# Altium schematic sheet MCU_CONTROL.SchDoc(MCU_CONTROL)
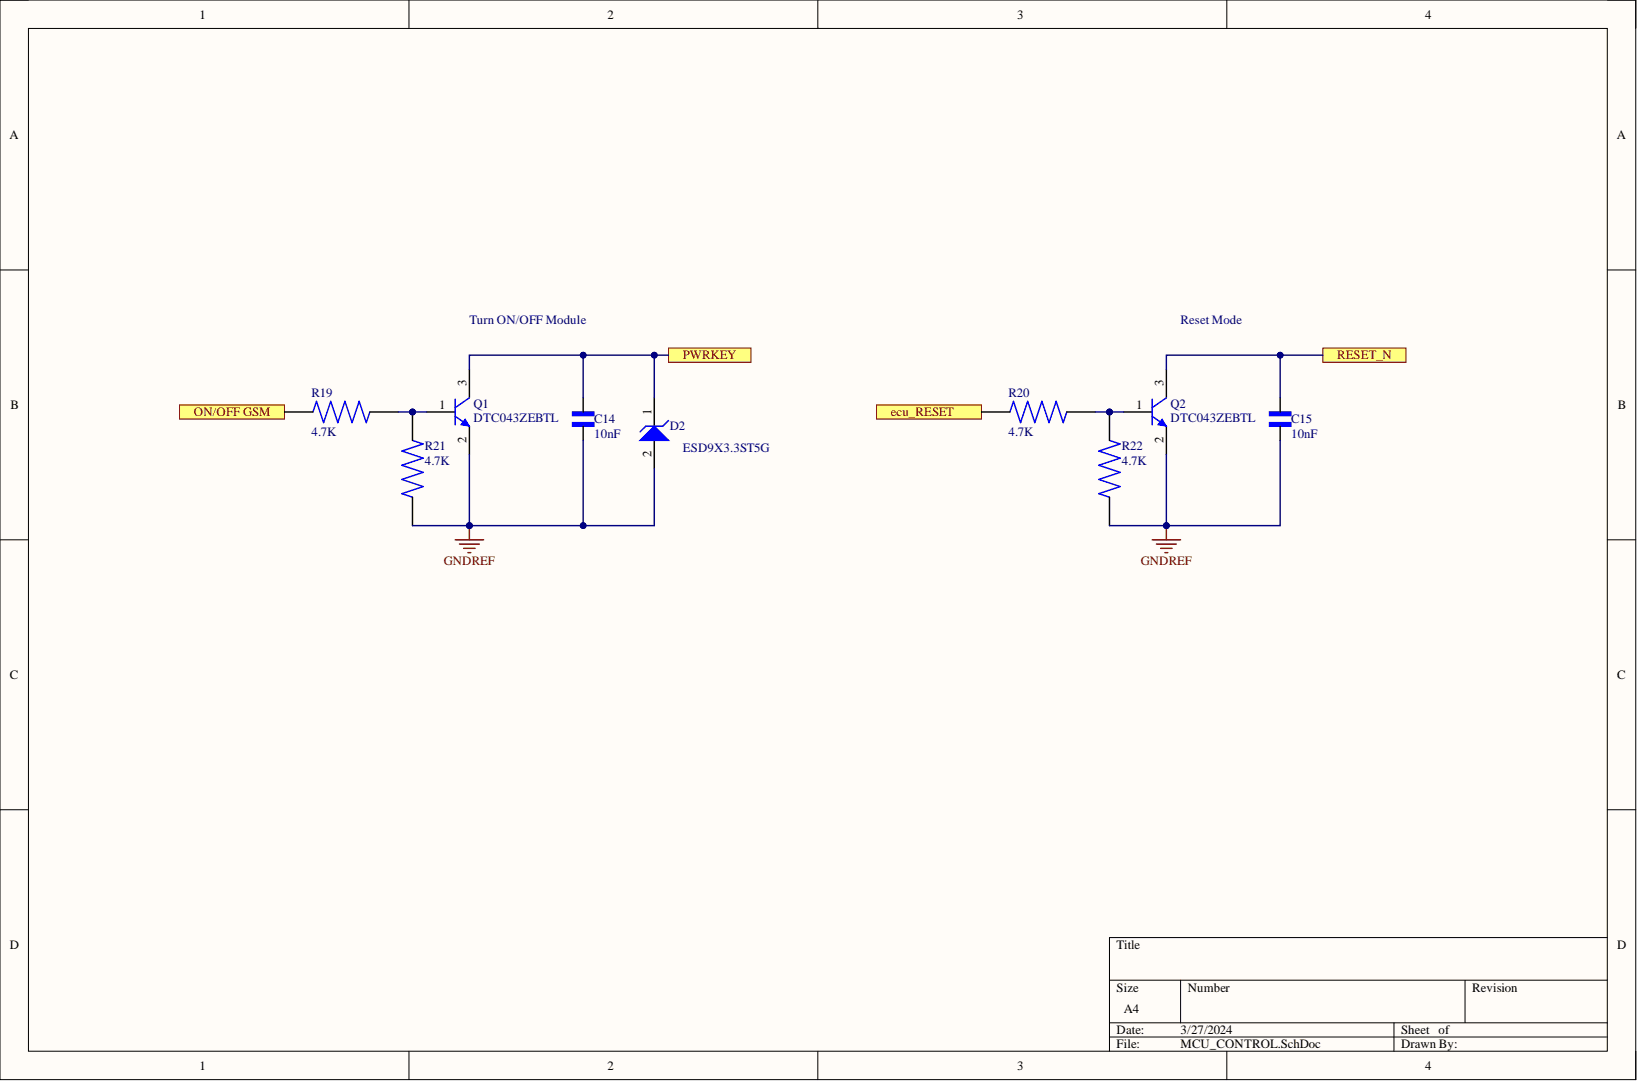
[diagram p0: MCU_CONTROL.SchDoc]

## Parts
C14  10nF
C15  10nF
D2  ESD9X3.3ST5G
Q1  DTC043ZEBTL
Q2  DTC043ZEBTL
R19  4.7K
R20  4.7K
R21  4.7K
R22  4.7K

## Ports
ecu_RESET, ON/OFF GSM, PWRKEY, RESET_N

## Nets
GNDREF: C14.1 C15.1 D2.2 Q1.2 Q2.2 R21.1 R22.1
ecu_RESET: R20.1 ; port ecu_RESET
ON/OFF GSM: R19.1 ; port ON/OFF GSM
PWRKEY: C14.2 D2.1 Q1.3 ; port PWRKEY
RESET_N: C15.2 Q2.3 ; port RESET_N
NetQ1_1: Q1.1 R19.2 R21.2
NetQ2_1: Q2.1 R20.2 R22.2

## Library data of the parts
C14 C15 = GCM188R72A103KA37D: .01UF 100V 10% 0603; MF: {'manufacturer': u'Murata'}; Availability: Unavailable; Component Kind: Standard; MP: GCM188R72A103KA37D; Package: None; Price: None
D2 = CMP-1063-00106-1: Transient Voltage Suppressor, Micro-Packaged Diode for ESD Protection, 2-Pin SOD-923, Pb-Free, Tape and Reel; Manufacturer: On Semiconductor; Direction: Unidirectional; IR Max (uA): 2.5; Number of Lines: 1; V(BR) Min (V): 5; VRWM Max (V): 3.3; Component Kind: Standard; ComponentLink1Description: Manufacturer URL; ComponentLink1URL: http://www.onsemi.com/; ComponentLink2Description: Datasheet; ComponentLink2URL: http://www.onsemi.cn/pub_link/Collateral/ESD9X3.3ST5G-D.PDF; ComponentLink3Description: Package Specification; ComponentLink3URL: http://www.onsemi.com/pub_link/Collateral/514AA.PDF; DatasheetVersion: Rev. 7; Library Name: ESD9X3.3ST5G.SchLib; Mounting Technology: Surface Mount; PackageDescription: 2-Pin SOD, Body 0.8 x 0.6 mm; PackageReference: SOD-923-2-514AA-01; PackageVersion: Rev. E, 03/2011; Packing: Tape and Reel; PartNumber: ESD9X3.3ST5G; RoHS: Pb-Free
Q1 Q2 = CMP-2000-05934-1: NPN Small Signal Transistor, 45 V, 500 mA, -65 to 150 degC, 3-Pin SOT323, RoHS, Tape and Reel; Brand: DiodesIncorporated; Collector- Base Voltage VCBO: 50V; Collector- Emitter Voltage VCEO Max: 45V; Collector-Emitter Saturation Voltage: 0.7V; Configuration: Single; DC Collector/Base Gain hfe Min: 250; Emitter- Base Voltage VEBO: 5V; Gain Bandwidth Product fT: 100MHz; Maximum DC Collector Current: 1A; Pd - Power Dissipation: 200mW; Unit Weight: 0.000282oz; Component Kind: Standard; Datasheet URL: http://www.diodes.com/_files/datasheets/ds30575.pdf; Datasheet Version: Rev. 5-2, 01/2014; Factory Pack Quantity: 3000; Height: 1mm; Length: 2.2mm; Library Name: DTC043ZEBTL.SchLib; Manufacturer: DiodesIncorporated; Manufacturer URL: http://www.diodes.com/; Maximum Operating Temperature: +150C; Minimum Operating Temperature: -65C; Mounting Style: SMD/SMT; Mounting Technology: SurfaceMount; Package / Case: SOT-23; Package Description: 3-Pin Small Outline Transistor, Body 2 x 1.25 mm, Pitch 0.65 mm; Package Reference: SOT323; Packaging: Reel; Product Category: BipolarTransistors-BJT; RoHS: True; Series: BC817; Transistor Polarity: NPN; Width: 1.35mm
R19-R22 = RC0402FR-074K7L: <a href="https://pricing.snapeda.com/parts/RC0402FR-074K7L/Yageo/view-part?ref=eda">Check availability</a>; MF: {'manufacturer': u'Yageo'}; Availability: Unavailable; Component Kind: Standard; MP: RC0402FR-074K7L; Package: None; Price: None
